# Altium schematic sheet x3399.SchDoc(x3399)
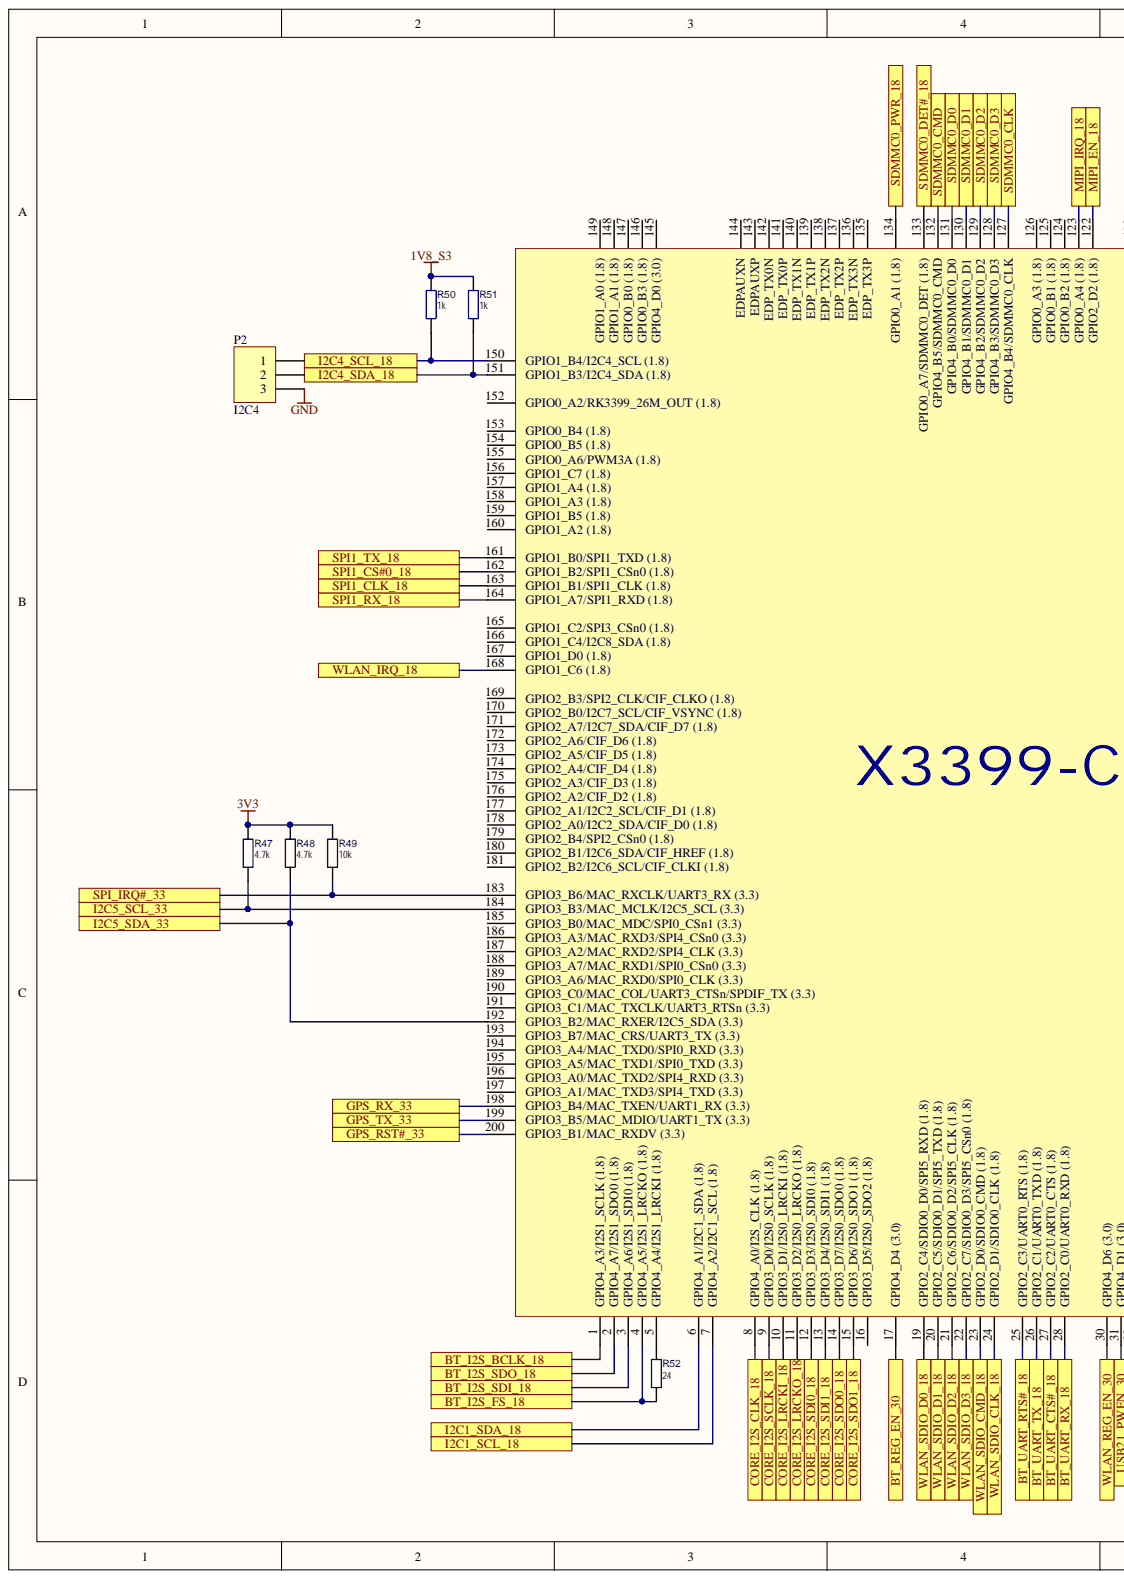
[diagram p0: x3399.SchDoc, left]
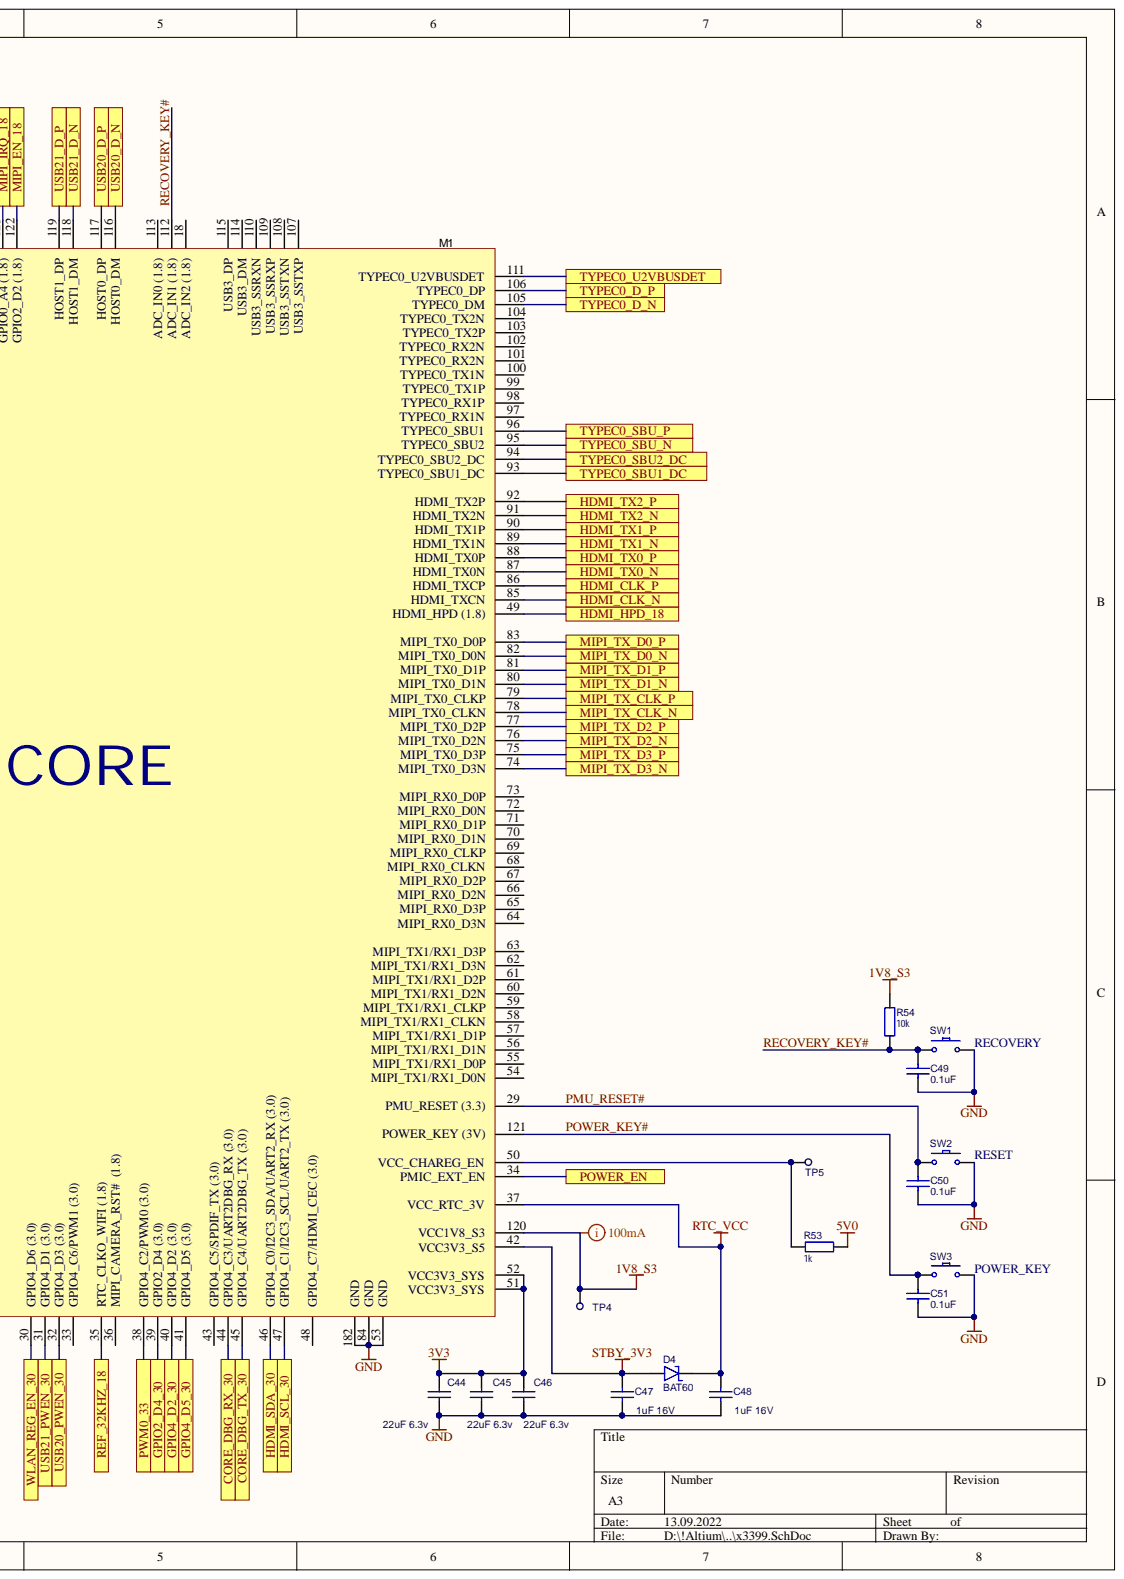
[diagram p1: x3399.SchDoc, right]

## Parts
C44  value 22uF 6.3v  [C0805]  (hidden comment: CL21A226MQQNNNE)
C45  value 22uF 6.3v  [C0805]  (hidden comment: CL21A226MQQNNNE)
C46  value 22uF 6.3v  [C0805]  (hidden comment: CL21A226MQQNNNE)
C47  value 1uF 16V  [C0603]  (hidden comment: GRM188R61H105KAALD)
C48  value 1uF 16V  [C0603]  (hidden comment: GRM188R61H105KAALD)
C49  value 0.1uF  [C0603]  (hidden comment: C1608X7R1H104K080AA)
C50  value 0.1uF  [C0603]  (hidden comment: C1608X7R1H104K080AA)
C51  value 0.1uF  [C0603]  (hidden comment: C1608X7R1H104K080AA)
D4  value BAT60  [SOD-323]  (hidden comment: BAT60AE6327HTSA1)
M1  X3399-CORE  [X3399-CORE]
P2  I2C4  [PLS-3]
R47  4.7k  [R0603]
R48  4.7k  [R0603]
R49  10k  [R0603]
R50  1k  [R0603]
R51  1k  [R0603]
R52  24  [R0603]
R53  1k  [R0603]
R54  10k  [R0603]
SW1  RECOVERY  [TACTM-34N-F]
SW2  RESET  [TACTM-34N-F]
SW3  POWER_KEY  [TACTM-34N-F]
TP4  TEST-POINT  [PAD]  (value not drawn)
TP5  TEST-POINT  [PAD]  (value not drawn)

## Ports
BT_I2S_BCLK_18, BT_I2S_FS_18, BT_I2S_SDI_18, BT_I2S_SDO_18, BT_REG_EN_30, BT_UART_CTS#_18, BT_UART_RTS#_18, BT_UART_RX_18, BT_UART_TX_18, CORE_DBG_RX_30, CORE_DBG_TX_30, CORE_I2S_CLK_18, CORE_I2S_LRCKI_18, CORE_I2S_LRCKO_18, CORE_I2S_SCLK_18, CORE_I2S_SDI0_18, CORE_I2S_SDI1_18, CORE_I2S_SDO0_18, CORE_I2S_SDO1_18, GPIO2_D4_30, GPIO4_D2_30, GPIO4_D5_30, GPS_RST#_33, GPS_RX_33, GPS_TX_33, HDMI_CLK_N, HDMI_CLK_P, HDMI_HPD_18, HDMI_SCL_30, HDMI_SDA_30, HDMI_TX0_N, HDMI_TX0_P, HDMI_TX1_N, HDMI_TX1_P, HDMI_TX2_N, HDMI_TX2_P, I2C1_SCL_18, I2C1_SDA_18, I2C4_SCL_18, I2C4_SDA_18, I2C5_SCL_33, I2C5_SDA_33, MIPI_EN_18, MIPI_IRQ_18, MIPI_TX_CLK_N, MIPI_TX_CLK_P, MIPI_TX_D0_N, MIPI_TX_D0_P, MIPI_TX_D1_N, MIPI_TX_D1_P, MIPI_TX_D2_N, MIPI_TX_D2_P, MIPI_TX_D3_N, MIPI_TX_D3_P, POWER_EN, PWM0_33, REF_32KHZ_18, SDMMC0_CLK, SDMMC0_CMD, SDMMC0_D0, SDMMC0_D1, SDMMC0_D2, SDMMC0_D3, SDMMC0_DET#_18, SDMMC0_PWR_18, SPI1_CLK_18, SPI1_CS#0_18, SPI1_RX_18, SPI1_TX_18, SPI_IRQ#_33, TYPEC0_D_N, TYPEC0_D_P, TYPEC0_SBU1_DC, TYPEC0_SBU2_DC, TYPEC0_SBU_N, TYPEC0_SBU_P, TYPEC0_U2VBUSDET, USB20_D_N, USB20_D_P, USB20_PWEN_30, USB21_D_N, USB21_D_P, USB21_PWEN_30, WLAN_IRQ_18, WLAN_REG_EN_30, WLAN_SDIO_CLK_18, WLAN_SDIO_CMD_18, WLAN_SDIO_D0_18, WLAN_SDIO_D1_18, WLAN_SDIO_D2_18, WLAN_SDIO_D3_18

## Nets
1V8_S3: M1.120(VCC1V8_S3) R50.2 R51.1 R54.2 TP4.1
3V3: C44.1 C45.1 C46.1 M1.51(VCC3V3_SYS) M1.52(VCC3V3_SYS) R47.2 R48.1 R49.1
5V0: R53.1
GND: C44.2 C45.2 C46.2 C47.1 C48.1 C49.2 C50.2 C51.2 M1.53(GND) M1.84(GND) M1.182(GND) P2.3 SW1.2 SW2.2 SW3.2
RTC_VCC: C48.2 D4.2 M1.37(VCC_RTC_3V)
STBY_3V3: C47.2 D4.1 M1.42(VCC3V3_S5)
BT_I2S_BCLK_18: M1.1(GPIO4_A3/I2S1_SCLK_(1.8)) ; port BT_I2S_BCLK_18
BT_I2S_FS_18: M1.4(GPIO4_A5/I2S1_LRCKO_(1.8)) R52.2 ; port BT_I2S_FS_18
BT_I2S_SDI_18: M1.3(GPIO4_A6/I2S1_SDI0_(1.8)) ; port BT_I2S_SDI_18
BT_I2S_SDO_18: M1.2(GPIO4_A7/I2S1_SDO0_(1.8)) ; port BT_I2S_SDO_18
BT_REG_EN_30: M1.17(GPIO4_D4_(3.0)) ; port BT_REG_EN_30
BT_UART_CTS#_18: M1.27(GPIO2_C2/UART0_CTS_(1.8)) ; port BT_UART_CTS#_18
BT_UART_RTS#_18: M1.25(GPIO2_C3/UART0_RTS_(1.8)) ; port BT_UART_RTS#_18
BT_UART_RX_18: M1.28(GPIO2_C0/UART0_RXD_(1.8)) ; port BT_UART_RX_18
BT_UART_TX_18: M1.26(GPIO2_C1/UART0_TXD_(1.8)) ; port BT_UART_TX_18
CORE_DBG_RX_30: M1.44(GPIO4_C3/UART2DBG_RX_(3.0)) ; port CORE_DBG_RX_30
CORE_DBG_TX_30: M1.45(GPIO4_C4/UART2DBG_TX_(3.0)) ; port CORE_DBG_TX_30
CORE_I2S_CLK_18: M1.8(GPIO4_A0/I2S_CLK_(1.8)) ; port CORE_I2S_CLK_18
CORE_I2S_LRCKI_18: M1.10(GPIO3_D1/I2S0_LRCKI_(1.8)) ; port CORE_I2S_LRCKI_18
CORE_I2S_LRCKO_18: M1.11(GPIO3_D2/I2S0_LRCKO_(1.8)) ; port CORE_I2S_LRCKO_18
CORE_I2S_SCLK_18: M1.9(GPIO3_D0/I2S0_SCLK_(1.8)) ; port CORE_I2S_SCLK_18
CORE_I2S_SDI0_18: M1.12(GPIO3_D3/I2S0_SDI0_(1.8)) ; port CORE_I2S_SDI0_18
CORE_I2S_SDI1_18: M1.13(GPIO3_D4/I2S0_SDI1_(1.8)) ; port CORE_I2S_SDI1_18
CORE_I2S_SDO0_18: M1.14(GPIO3_D7/I2S0_SDO0_(1.8)) ; port CORE_I2S_SDO0_18
CORE_I2S_SDO1_18: M1.15(GPIO3_D6/I2S0_SDO1_(1.8)) ; port CORE_I2S_SDO1_18
GPIO2_D4_30: M1.39(GPIO2_D4_(3.0)) ; port GPIO2_D4_30
GPIO4_D2_30: M1.40(GPIO4_D2_(3.0)) ; port GPIO4_D2_30
GPIO4_D5_30: M1.41(GPIO4_D5_(3.0)) ; port GPIO4_D5_30
GPS_RST#_33: M1.200(GPIO3_B1/MAC_RXDV_(3.3)) ; port GPS_RST#_33
GPS_RX_33: M1.198(GPIO3_B4/MAC_TXEN/UART1_RX_(3.3)) ; port GPS_RX_33
GPS_TX_33: M1.199(GPIO3_B5/MAC_MDIO/UART1_TX_(3.3)) ; port GPS_TX_33
HDMI_CLK_N: M1.85(HDMI_TXCN) ; port HDMI_CLK_N
HDMI_CLK_P: M1.86(HDMI_TXCP) ; port HDMI_CLK_P
HDMI_HPD_18: M1.49(HDMI_HPD_(1.8)) ; port HDMI_HPD_18
HDMI_SCL_30: M1.47(GPIO4_C1/I2C3_SCL/UART2_TX_(3.0)) ; port HDMI_SCL_30
HDMI_SDA_30: M1.46(GPIO4_C0/I2C3_SDA/UART2_RX_(3.0)) ; port HDMI_SDA_30
HDMI_TX0_N: M1.87(HDMI_TX0N) ; port HDMI_TX0_N
HDMI_TX0_P: M1.88(HDMI_TX0P) ; port HDMI_TX0_P
HDMI_TX1_N: M1.89(HDMI_TX1N) ; port HDMI_TX1_N
HDMI_TX1_P: M1.90(HDMI_TX1P) ; port HDMI_TX1_P
HDMI_TX2_N: M1.91(HDMI_TX2N) ; port HDMI_TX2_N
HDMI_TX2_P: M1.92(HDMI_TX2P) ; port HDMI_TX2_P
I2C1_SCL_18: M1.7(GPIO4_A2/I2C1_SCL_(1.8)) ; port I2C1_SCL_18
I2C1_SDA_18: M1.6(GPIO4_A1/I2C1_SDA_(1.8)) ; port I2C1_SDA_18
I2C4_SCL_18: M1.150(GPIO1_B4/I2C4_SCL_(1.8)) P2.1 R50.1 ; port I2C4_SCL_18
I2C4_SDA_18: M1.151(GPIO1_B3/I2C4_SDA_(1.8)) P2.2 R51.2 ; port I2C4_SDA_18
I2C5_SCL_33: M1.184(GPIO3_B3/MAC_MCLK/I2C5_SCL_(3.3)) R47.1 ; port I2C5_SCL_33
I2C5_SDA_33: M1.192(GPIO3_B2/MAC_RXER/I2C5_SDA_(3.3)) R48.2 ; port I2C5_SDA_33
MIPI_EN_18: M1.122(GPIO2_D2_(1.8)) ; port MIPI_EN_18
MIPI_IRQ_18: M1.123(GPIO0_A4_(1.8)) ; port MIPI_IRQ_18
MIPI_TX_CLK_N: M1.78(MIPI_TX0_CLKN) ; port MIPI_TX_CLK_N
MIPI_TX_CLK_P: M1.79(MIPI_TX0_CLKP) ; port MIPI_TX_CLK_P
MIPI_TX_D0_N: M1.82(MIPI_TX0_D0N) ; port MIPI_TX_D0_N
MIPI_TX_D0_P: M1.83(MIPI_TX0_D0P) ; port MIPI_TX_D0_P
MIPI_TX_D1_N: M1.80(MIPI_TX0_D1N) ; port MIPI_TX_D1_N
MIPI_TX_D1_P: M1.81(MIPI_TX0_D1P) ; port MIPI_TX_D1_P
MIPI_TX_D2_N: M1.76(MIPI_TX0_D2N) ; port MIPI_TX_D2_N
MIPI_TX_D2_P: M1.77(MIPI_TX0_D2P) ; port MIPI_TX_D2_P
MIPI_TX_D3_N: M1.74(MIPI_TX0_D3N) ; port MIPI_TX_D3_N
MIPI_TX_D3_P: M1.75(MIPI_TX0_D3P) ; port MIPI_TX_D3_P
PMU_RESET#: C50.1 M1.29(PMU_RESET_(3.3)) SW2.1
POWER_EN: M1.34(PMIC_EXT_EN) ; port POWER_EN
POWER_KEY#: C51.1 M1.121(POWER_KEY_(3V)) SW3.1
PWM0_33: M1.38(GPIO4_C2/PWM0_(3.0)) ; port PWM0_33
RECOVERY_KEY#: C49.1 M1.112(ADC_IN1_(1.8)) R54.1 SW1.1
REF_32KHZ_18: M1.35(RTC_CLKO_WIFI_(1.8)) ; port REF_32KHZ_18
SDMMC0_CLK: M1.127(GPIO4_B4/SDMMC0_CLK) ; port SDMMC0_CLK
SDMMC0_CMD: M1.132(GPIO4_B5/SDMMC0_CMD) ; port SDMMC0_CMD
SDMMC0_D0: M1.131(GPIO4_B0/SDMMC0_D0) ; port SDMMC0_D0
SDMMC0_D1: M1.130(GPIO4_B1/SDMMC0_D1) ; port SDMMC0_D1
SDMMC0_D2: M1.129(GPIO4_B2/SDMMC0_D2) ; port SDMMC0_D2
SDMMC0_D3: M1.128(GPIO4_B3/SDMMC0_D3) ; port SDMMC0_D3
SDMMC0_DET#_18: M1.133(GPIO0_A7/SDMMC0_DET_(1.8)) ; port SDMMC0_DET#_18
SDMMC0_PWR_18: M1.134(GPIO0_A1_(1.8)) ; port SDMMC0_PWR_18
SPI1_CLK_18: M1.163(GPIO1_B1/SPI1_CLK_(1.8)) ; port SPI1_CLK_18
SPI1_CS#0_18: M1.162(GPIO1_B2/SPI1_CSn0_(1.8)) ; port SPI1_CS#0_18
SPI1_RX_18: M1.164(GPIO1_A7/SPI1_RXD_(1.8)) ; port SPI1_RX_18
SPI1_TX_18: M1.161(GPIO1_B0/SPI1_TXD_(1.8)) ; port SPI1_TX_18
SPI_IRQ#_33: M1.183(GPIO3_B6/MAC_RXCLK/UART3_RX_(3.3)) R49.2 ; port SPI_IRQ#_33
TYPEC0_D_N: M1.105(TYPEC0_DM) ; port TYPEC0_D_N
TYPEC0_D_P: M1.106(TYPEC0_DP) ; port TYPEC0_D_P
TYPEC0_SBU1_DC: M1.93(TYPEC0_SBU1_DC) ; port TYPEC0_SBU1_DC
TYPEC0_SBU2_DC: M1.94(TYPEC0_SBU2_DC) ; port TYPEC0_SBU2_DC
TYPEC0_SBU_N: M1.95(TYPEC0_SBU2) ; port TYPEC0_SBU_N
TYPEC0_SBU_P: M1.96(TYPEC0_SBU1) ; port TYPEC0_SBU_P
TYPEC0_U2VBUSDET: M1.111(TYPEC0_U2VBUSDET) ; port TYPEC0_U2VBUSDET
USB20_D_N: M1.116(HOST0_DM) ; port USB20_D_N
USB20_D_P: M1.117(HOST0_DP) ; port USB20_D_P
USB20_PWEN_30: M1.32(GPIO4_D3_(3.0)) ; port USB20_PWEN_30
USB21_D_N: M1.118(HOST1_DM) ; port USB21_D_N
USB21_D_P: M1.119(HOST1_DP) ; port USB21_D_P
USB21_PWEN_30: M1.31(GPIO4_D1_(3.0)) ; port USB21_PWEN_30
WLAN_IRQ_18: M1.168(GPIO1_C6_(1.8)) ; port WLAN_IRQ_18
WLAN_REG_EN_30: M1.30(GPIO4_D6_(3.0)) ; port WLAN_REG_EN_30
WLAN_SDIO_CLK_18: M1.24(GPIO2_D1/SDIO0_CLK_(1.8)) ; port WLAN_SDIO_CLK_18
WLAN_SDIO_CMD_18: M1.23(GPIO2_D0/SDIO0_CMD_(1.8)) ; port WLAN_SDIO_CMD_18
WLAN_SDIO_D0_18: M1.19(GPIO2_C4/SDIO0_D0/SPI5_RXD_(1.8)) ; port WLAN_SDIO_D0_18
WLAN_SDIO_D1_18: M1.20(GPIO2_C5/SDIO0_D1/SPI5_TXD_(1.8)) ; port WLAN_SDIO_D1_18
WLAN_SDIO_D2_18: M1.21(GPIO2_C6/SDIO0_D2/SPI5_CLK_(1.8)) ; port WLAN_SDIO_D2_18
WLAN_SDIO_D3_18: M1.22(GPIO2_C7/SDIO0_D3/SPI5_CSn0_(1.8)) ; port WLAN_SDIO_D3_18
NetM1_5: M1.5(GPIO4_A4/I2S1_LRCKI_(1.8)) R52.1
NetM1_50: M1.50(VCC_CHAREG_EN) R53.2 TP5.1

Not connected: M1 16(GPIO3_D5/I2S0_SDO2_(1.8)) 18(ADC_IN2_(1.8)) 33(GPIO4_C6/PWM1_(3.0)) 36(MIPI_CAMERA_RST#) 43(GPIO4_C5/SPDIF_TX_(3.0)) 48(GPIO4_C7/HDMI_CEC_(3.0)) 54(MIPI_TX1/RX1_D0N) 55(MIPI_TX1/RX1_D0P) 56(MIPI_TX1/RX1_D1N) 57(MIPI_TX1/RX1_D1P) 58(MIPI_TX1/RX1_CLKN) 59(MIPI_TX1/RX1_CLKP) 60(MIPI_TX1/RX1_D2N) 61(MIPI_TX1/RX1_D2P) 62(MIPI_TX1/RX1_D3N) 63(MIPI_TX1/RX1_D3P) 64(MIPI_RX0_D3N) 65(MIPI_RX0_D3P) 66(MIPI_RX0_D2N) 67(MIPI_RX0_D2P) 68(MIPI_RX0_CLKN) 69(MIPI_RX0_CLKP) 70(MIPI_RX0_D1N) 71(MIPI_RX0_D1P) 72(MIPI_RX0_D0N) 73(MIPI_RX0_D0P) 97(TYPEC0_RX1N) 98(TYPEC0_RX1P) 99(TYPEC0_TX1P) 100(TYPEC0_TX1N) 101(TYPEC0_RX2N) 102(TYPEC0_RX2N) 103(TYPEC0_TX2P) 104(TYPEC0_TX2N) 107(USB3_SSTXP) 108(USB3_SSTXN) 109(USB3_SSRXP) 110(USB3_SSRXN) 113(ADC_IN0_(1.8)) 114(USB3_DM) 115(USB3_DP) 124(GPIO0_B2_(1.8)) 125(GPIO0_B1_(1.8)) 126(GPIO0_A3_(1.8)) 135(EDP_TX3P) 136(EDP_TX3N) 137(EDP_TX2P) 138(EDP_TX2N) 139(EDP_TX1P) 140(EDP_TX1N) 141(EDP_TX0P) 142(EDP_TX0N) 143(EDPAUXP) 144(EDPAUXN) 145(GPIO4_D0_(3.0)) 146(GPIO0_B3_(1.8)) 147(GPIO0_B0_(1.8)) 148(GPIO1_A1_(1.8)) 149(GPIO1_A0_(1.8)) 152(GPIO0_A2/RK3399_26M_OUT_(1.8)) 153(GPIO0_B4_(1.8)) 154(GPIO0_B5_(1.8)) 155(GPIO0_A6/PWM3A_(1.8)) 156(GPIO1_C7_(1.8)) 157(GPIO1_A4_(1.8)) 158(GPIO1_A3_(1.8)) 159(GPIO1_B5_(1.8)) 160(GPIO1_A2_(1.8)) 165(GPIO1_C2/SPI3_CSn0_(1.8)) 166(GPIO1_C4/I2C8_SDA_(1.8)) 167(GPIO1_D0_(1.8)) 169(GPIO2_B3/SPI2_CLK/CIF_CLKO_(1.8)) 170(GPIO2_B0/I2C7_SCL/CIF_VSYNC_(1.8)) 171(GPIO2_A7/I2C7_SDA/CIF_D7_(1.8)) 172(GPIO2_A6/CIF_D6_(1.8)) 173(GPIO2_A5/CIF_D5_(1.8)) 174(GPIO2_A4/CIF_D4_(1.8)) 175(GPIO2_A3/CIF_D3_(1.8)) 176(GPIO2_A2/CIF_D2_(1.8)) 177(GPIO2_A1/I2C2_SCL/CIF_D1_(1.8)) 178(GPIO2_A0/I2C2_SDA/CIF_D0_(1.8)) 179(GPIO2_B4/SPI2_CSn0_(1.8)) 180(GPIO2_B1/I2C6_SDA/CIF_HREF_(1.8)) 181(GPIO2_B2/I2C6_SCL/CIF_CLKI_(1.8)) 185(GPIO3_B0/MAC_MDC/SPI0_CSn1_(3.3)) 186(GPIO3_A3/MAC_RXD3/SPI4_CSn0_(3.3)) 187(GPIO3_A2/MAC_RXD2/SPI4_CLK_(3.3)) 188(GPIO3_A7/MAC_RXD1/SPI0_CSn0_(3.3)) 189(GPIO3_A6/MAC_RXD0/SPI0_CLK_(3.3)) 190(GPIO3_C0/MAC_COL/UART3_CTSn/SPDIF_TX_(3.3)) 191(GPIO3_C1/MAC_TXCLK/UART3_RTSn_(3.3)) 193(GPIO3_B7/MAC_CRS/UART3_TX_(3.3)) 194(GPIO3_A4/MAC_TXD0/SPI0_RXD_(3.3)) 195(GPIO3_A5/MAC_TXD1/SPI0_TXD_(3.3)) 196(GPIO3_A0/MAC_TXD2/SPI4_RXD_(3.3)) 197(GPIO3_A1/MAC_TXD3/SPI4_TXD_(3.3))

## Library data of the parts
C44-C51 = CAP_NPOL: Library Name: capacitors.SchLib; Description: CAP_NPOL; Component Kind: Standard
D4 = SHOTTKY: Library Name: diodes.SchLib; Description: SHOTTKY; Component Kind: Standard
M1 = X3399-CORE: RK3399 Six-Core 64-bit; Library Name: X3399-CORE.SchLib; Component Kind: Standard
P2 = HDR 3: Header, 3-Pin; Library Name: HDR.SchLib; LatestRevisionNote: Re-released for DXP Platform.; LatestRevisionDate: 17-Jul-2002; Component Kind: Standard; Publisher: Altium Limited
R47-R54 = RESISTOR: RES; Library Name: resistors.SchLib; Component Kind: Standard
  R47 R48: Value: 4.7k
  R49 R54: Value: 10k
  R50 R51 R53: Value: 1k
  R52: Value: 24
SW1-SW3 = SW tact 2-pin: Microswitches, DTSMB-3 series; Value: SW tact 2-pin; Library Name: SW-TACT-2PIN.SchLib; Component Kind: Standard
TP4 TP5 = TEST-POINT: PCB terminal block; Library Name: TestPoint.SchLib; Component Kind: Standard
